# Altium schematic sheet BSPD_SHEET_2.SchDoc(BSPD_SHEET_2)
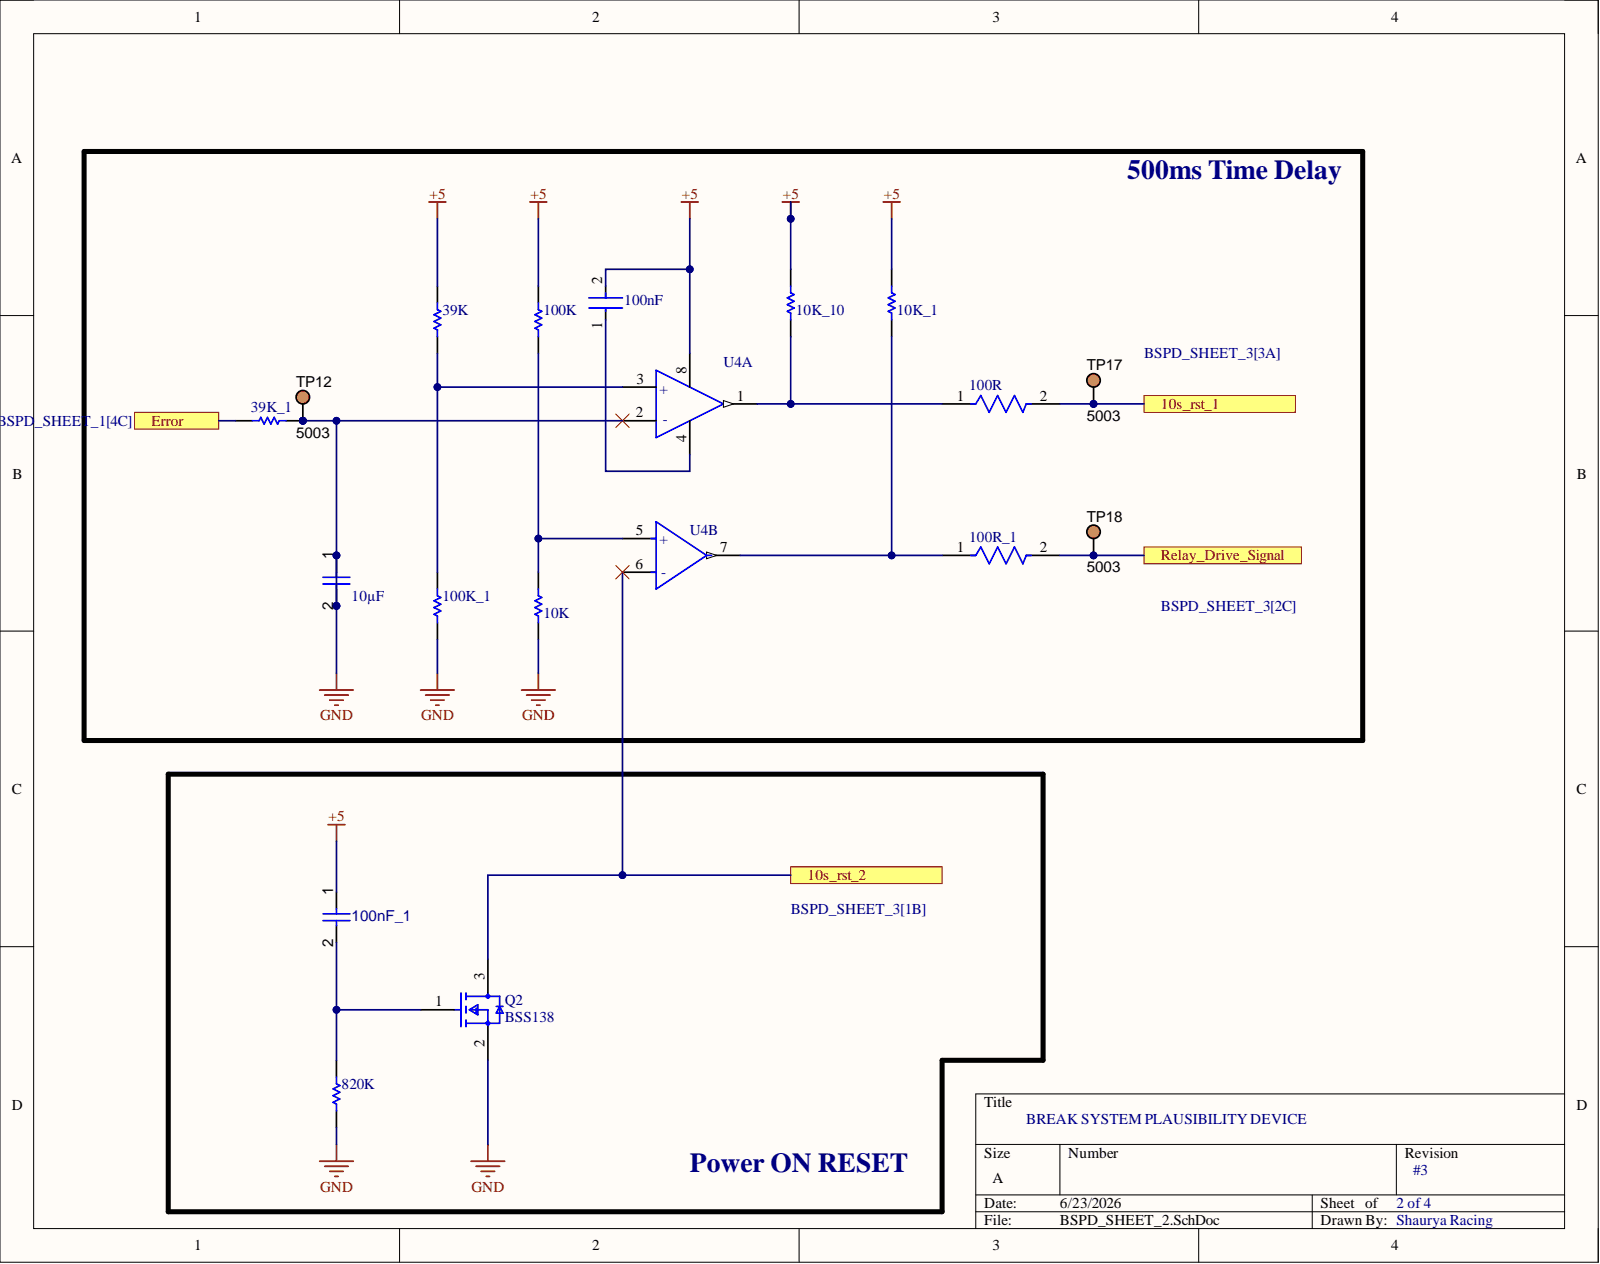
[diagram p0: BSPD_SHEET_2.SchDoc]

## Parts
10K  TNPW040210K0DEED  (value not drawn)
10K_1  TNPW040210K0DEED  (value not drawn)
10K_10  TNPW040210K0DEED  (value not drawn)
10uF  value 10µF  (hidden comment: Capacitor 10µF +/-40% 6.3V 0402)
39K  CRCW020139K0JNED  (value not drawn)
39K_1  CRCW020139K0JNED  (value not drawn)
100K  100K 1% 0603(1608)  (value not drawn)
100K_1  100K 1% 0603(1608)  (value not drawn)
100nF  AC1210KKX7R0BB104  (value not drawn)
100nF_1  Capacitor 100nF +/-20% 25V 0402  (value not drawn)
100R  RN73C1E100RBTDF  (value not drawn)
100R_1  RN73C1E100RBTDF  (value not drawn)
820K  820K 5% 1206(3216)  (value not drawn)
Q2  BSS138
TP12  5003
TP17  5003
TP18  5003
U4  LM393D  (value not drawn)  (parts A,B)

## Ports
10s_rst_1, 10s_rst_2, Error, Relay_Drive_Signal

## Nets
+5: 10K_1.2 10K_10.2 39K.1 100K.2 100nF.2 100nF_1.1 U4.8
GND: 10K.1 10uF.1 10uF.2 39K_1.2 100K_1.1 820K.2 Q2.2 TP12.1 U4.2(-)
10s_rst_1: 100R.2 TP17.1 ; port 10s_rst_1
10s_rst_2: Q2.3 U4.6(-) ; port 10s_rst_2
Error: 39K_1.1 ; port Error
Relay_Drive_Signal: 100R_1.2 TP18.1 ; port Relay_Drive_Signal
Net10K_1_1: 10K_1.1 100R_1.1 U4.7
Net10K_2: 10K.2 100K.1 U4.5(+)
Net10K_10_1: 10K_10.1 100R.1 U4.1
Net39K_2: 39K.2 100K_1.2 U4.3(+)
Net100nF_1: 100nF.1 U4.4
Net100nF_1_2: 100nF_1.2 820K.1 Q2.1

## Library data of the parts
10K 10K_1 10K_10 = CMP-2002-01682-1: Manufacturer: Vishay BCcomponents; Component Kind: Standard; Library Name: Altium Content Vault; Part Number: TNPW040210K0DEED
10uF = CMP-001-00074-3: Chip Capacitor, 10µF +/-40%, 6.3V, 0402, Thickness 0.6 mm; Tolerance: 10%; Case/Package: 0402; Component Kind: Standard; Max Operating Temperature: 85°C; Min Operating Temperature: -55°C; Mounting Technology: SMT; Pins: 2; Voltage Rating: 6.3V; Library Name: APAC - VIT, Chennai - Shaurya Racing
39K 39K_1 = CMP-2004-00545-1: Manufacturer: Vishay Dale; Component Kind: Standard; Library Name: Altium Content Vault; Part Number: CRCW020139K0JNED
100K 100K_1 = CMP-1012-00736-1: 100K 0.1W 1% 0603 (1608 Metric)  SMD; Case-EIA: 0603; Case-Metric: 1608; Value: 100000.00 Ohm; Component Kind: Standard; Library Name: Altium Content Vault; Power: 0.1 W; Technology: SMT; Tolerance: 1 %
100nF = CMP-03422-000642-1: CAP CER 0.1UF 100V X7R 1210; Manufacturer: Yageo; Automotive Grade: Grade 1; Capacitance: 100 nF; Temperature Coefficient: 15%; Tolerance: 0.1; Value: 100 nF; Automotive: Yes; Category: Cap; Component Kind: Standard; ComponentLink2Description: Datasheet; ComponentLink2URL: http://www.yageo.com/documents/recent/UPY-AC_NP0X7R_16V-to-630V_12.pdf; Device Class L1: Passive Components; Device Class L2: Capacitors; Device Class L3: Ceramic Capacitors; Digikey Description: CAP CER 0.1UF 100V X7R 1210; Footprint Url: http://www.yageo.com/exep/pages/download/literatures/UPY-C_GEN_24.pdf; Height: 0.95mm; Ipc Land Pattern Name: CAPC320250X85; Lead Free: Yes; Library Name: Altium Content Vault; Manufacturer Part Number: AC1210KKX7R0BB104; Material: Ceramic; Octopart: https://octopart.com/ac1210kkx7r0bb104-yageo-78873719; Package: AC1210-0.85; RoHS: TRUE; Temperature: -55°C to +125°C; Temperature Characteristic: X7R; Temperature Range High: +125°C; Temperature Range Low: -55°C; Voltage Rating: 100 V
100nF_1 = CMP-001-00065-4: Chip Capacitor, 100nF +/-20%, 25V, 0402, Thickness 0.6 mm; Tolerance: 10%; Case/Package: 0402; Component Kind: Standard; Max Operating Temperature: 85°C; Min Operating Temperature: -55°C; Mounting Technology: SMT; Pins: 2; Value: 100nF; Voltage Rating: 25V; Library Name: APAC - VIT, Chennai - Shaurya Racing
100R 100R_1 = CMP-2002-03415-2: Res Thin Film 0402 100Ω 0.1% 1/16W 10ppm/°C Molded SMD; Manufacturer: TE Connectivity; Power Rating: 0.063W; Voltage Rating: 25V; Automotive: No; Category: Res; Component Kind: Standard; ComponentLink2Description: Datasheet; ComponentLink2URL: https://www.te.com/commerce/DocumentDelivery/DDEController?Action=showdoc&DocId=Data+Sheet%7F1773270%7FP%7Fpdf%7FEnglish%7FENG_DS_1773270_P.pdf%7F1-1879132-6; Composition: Thin Film; Device Class L1: Passive Components; Device Class L2: Resistors; Device Class L3: Chip SMD Resistors; Height: 0.35mm; Ipc Land Pattern Name: RESC10050X30; Lead Free: Yes; Library Name: Altium Content Vault; Manufacturer Part Number: RN73C1E100RBTDF; Octopart: https://octopart.com/rn73c1e100rbtdf-te+connectivity-22738037; octopart_url: https://octopart.com/rn73c1e100rbtdf-te+connectivity-22738037; Package: RN731E; packaging: 1000 Reel; Resistance: 100Ω; RoHS: TRUE; Series: RN73; Temperature: -55°C to +155°C; Temperature Coefficient: 10ppm/°C; Temperature Range High: +155°C; Temperature Range Low: -55°C; Tolerance: 0.1%; Value: 100Ω
820K = CMP-1014-00144-1: 820K 0.25W 5% 1206 (3216 Metric)  SMD; Case-EIA: 1206; Case-Metric: 3216; Value: 820000.00 Ohm; Component Kind: Standard; Library Name: Altium Content Vault; Power: 0.25 W; Technology: SMT; Tolerance: 5 %
Q2 = CMP-07173-000101-1: MOSFET N-CH 50V 220MA SOT-23; Drain to Source Resistance: 3.5R; Element Configuration: Single; Fall Time: 9ns; Gate to Source Voltage (Vgs): 20V; Input Capacitance: 27pF; Max Power Dissipation: 360mW; Nominal Vgs: 1.3V; Number of Elements: 1; On-State Resistance: 6R; Turn-Off Delay Time: 20ns; Turn-On Delay Time: 2.5ns; Case/Package: SOT-23; Component Kind: Standard; Contact Plating: Tin; Continuous Drain Current (ID): 220mA; Current Rating: 220mA; Drain to Source Breakdown Voltage: 50V; Drain to Source Voltage (Vdss): 50V; Dual Supply Voltage: 50V; Height: 0.93mm; Lead Free: Lead Free; Length: 2.92mm; Library Name: Altium Content Vault; Max Operating Temperature: 150°C; Min Operating Temperature: -55°C; Mount: Surface Mount; Number of Pins: 3; Package Quantity: 1; Packaging: Tape and Reel; Power Dissipation: 360mW; Radiation Hardening: No; Rds On Max: 3.5R; REACH SVHC: No SVHC; Rise Time: 9ns; Termination: SMD/SMT; Threshold Voltage: 1.3V; Voltage Rating (DC): 50V; Weight: 0.03g; Width: 1.3mm
TP12 TP17 TP18 = CMP-002-00037-2: Test Point, Orange, Height 4.6 mm, Tail Length 3 mm, 1-Pin THD, RoHS; Manufacturer: Keystone; Case/Package: 5003; Colour: Orange; Component Kind: Standard; Connector Type: TestPoint; Contact Material: Bronze; Contact Plating: Tin; Datasheet URL: http://keyelco.com/userAssets/file/M65p56.pdf; Datasheet Version: Jun-15; Diameter: 2.54mm; Manufacturer URL: http://www.keyelco.com/; Mounting Technology: TH; Package Description: 1-Pin Through Hole Device, Dia 2.54 mm; Package Quantity: 10; Package Reference: 5003; Packaging: Bulk; Pins: 1; RoHS Compliant: True; Library Name: APAC - VIT, Chennai - Shaurya Racing
U4 = CMP-12132-000003-1: IC VOLT COMPARATOR DUAL LP 8SOIC; Manufacturer: STMicroelectronics; Input Bias Current: 0.02uA; Input Offset Voltage: 1mV; Max Dual Supply Voltage: 18V; Max Junction Temp: +150°C; Max Supply Voltage: 36V; Min Dual Supply Voltage: 1V; Min Supply Voltage: 2V; Nominal Supply Current: 0.45-0.6mA; Number Of Channels: 2; Output Current Per Channel: 18mA; Response Time: 1.3us; Standoff Height: 0.1mm; Voltage Gain: 200V/mV; Ambient Temperature Range High: +70°C; Ambient Temperature Range Low: 0°C; Automotive: No; Category: IC; Component Kind: Standard; ComponentLink2Description: Datasheet; ComponentLink2URL: https://www.st.com/resource/en/datasheet/lm393.pdf; Device Class L1: Integrated Circuits (ICs); Device Class L2: Linear ICs; Device Class L3: Comparators; Digikey Description: IC VOLT COMPARATOR DUAL LP 8SOIC; Footprint Url: https://www.st.com/resource/en/datasheet/stm8l001j3.pdf; Height: 1.75mm; Lead Free: Yes; Library Name: Altium Content Vault; Manufacturer Part Number: LM393D; Mouser Description: Analog Comparators Lo-Pwr Dual Voltage; Octopart: https://octopart.com/lm393d-stmicroelectronics-25832; Package: SO8; RoHS: TRUE; Temperature: 0°C to +70°C; Temperature Range High: +70°C; Temperature Range Low: 0°C
